# Altium schematic sheet OTP6_PingCircuit.SchDoc(OTP6_PingCircuit)
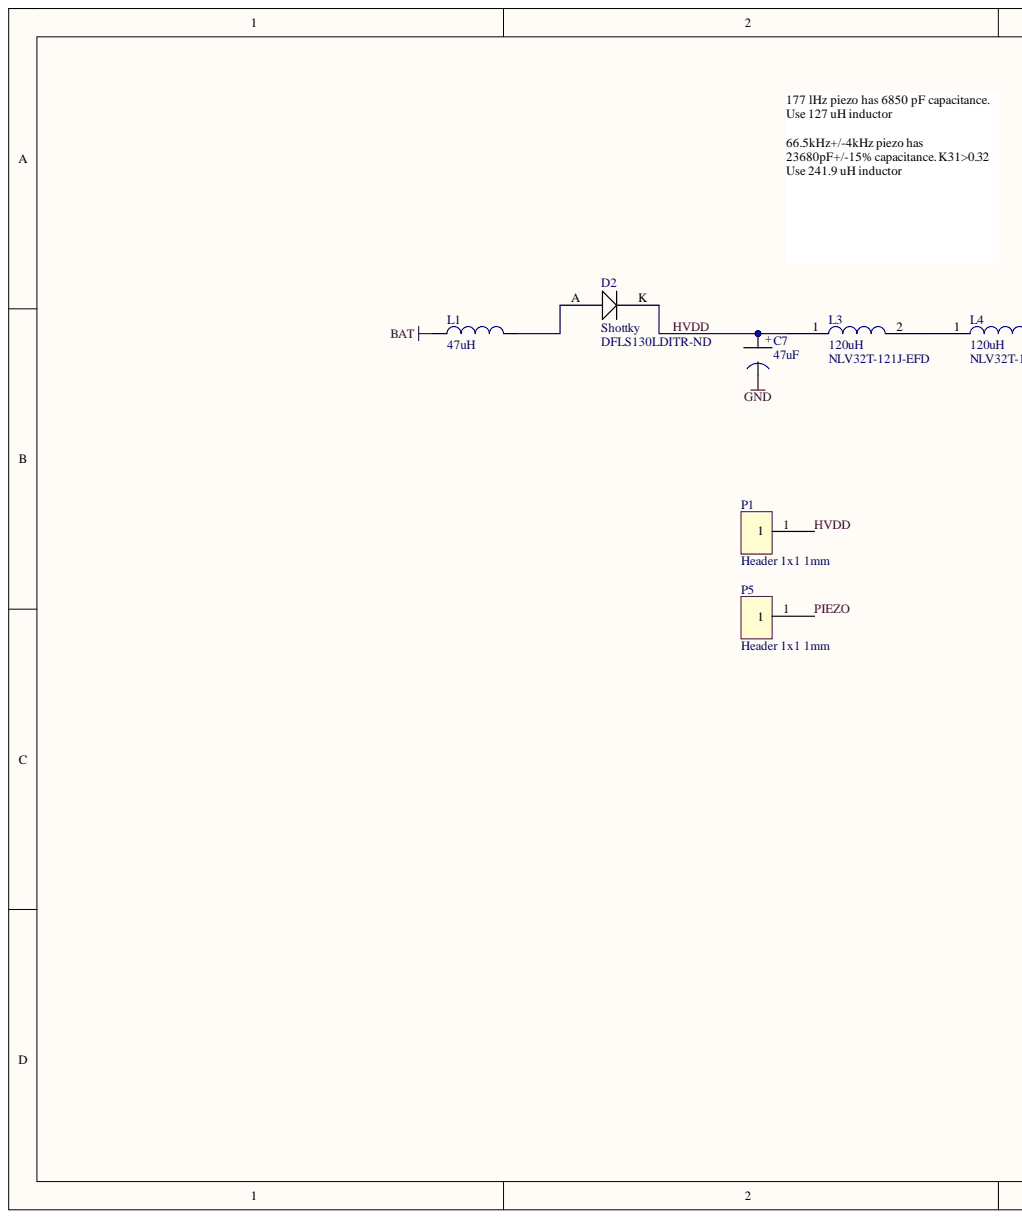
[diagram p0: OTP6_PingCircuit.SchDoc, left]
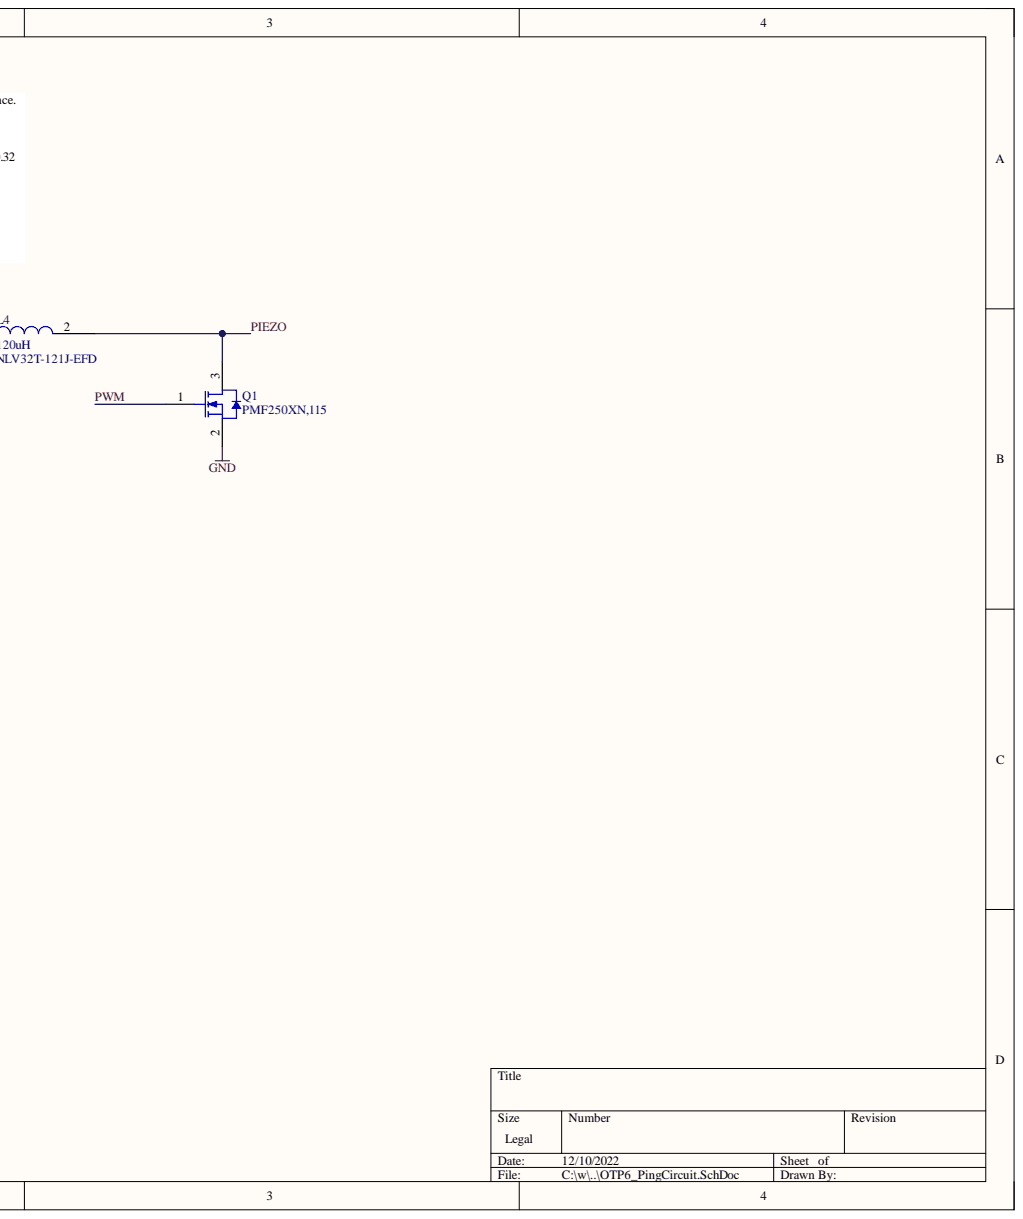
[diagram p1: OTP6_PingCircuit.SchDoc, right]

## Parts
C7  47uF  [Tant0805]
D2  Shottky  Supplier Part Number 1: DFLS130LDITR-ND  [DFLS130]
L1  47uH  [Inductor KLZ1608AHR]
L3  120uH  Supplier Part Number 1: NLV32T-121J-EFD  [Inductor_NLV32T]
L4  120uH  Supplier Part Number 1: NLV32T-121J-EFD  [Inductor_NLV32T]
P1  Header 1x1 1mm  [Header1x1mm]
P5  Header 1x1 1mm  [Header1x1mm]
Q1  PMF250XN,115  [NXP-SOT323_N]

## Nets
BAT: L1.1
GND: C7.1 Q1.2
HVDD: C7.2 D2.K L3.1 P1.1
PIEZO: L4.2 P5.1 Q1.3
PWM: Q1.1
NetD2_A: D2.A L1.2
NetL3_2: L3.2 L4.1

## Library data of the parts
C7 = Tant0805: Value: 10uF; Component Kind: Standard; Library Name: Loggerhead.IntLib
D2 = DFLS130: Component Kind: Standard; Supplier 1: Digi-Key; Library Name: Loggerhead.IntLib
L1 = Inductor KLZ1608: Component Kind: Standard; Supplier 1: Mouser; Supplier Part Number 1: 810-KLZ1608AHR2R2WTD; Library Name: Loggerhead.IntLib
L3 L4 = Inductor_NLV32T: Component Kind: Standard; Supplier 1: Mouser; Library Name: Loggerhead.IntLib
P1 P5 = Header 1x1 1mm: 1mm through hole; Component Kind: Standard; Library Name: Loggerhead.IntLib
Q1 = PMF250XN,115: N-Channel Trench MOSFET, 30 V, 0.9 A, -55 to 150 degC, 3-Pin SOT323, Tape and Reel; Manufacturer: NXP Semiconductors; Channel Type: N; Ciss [typ] (pF): 50; Coss [typ] (pF): 10; ID [max] (A): 0.9; Packing: Tape & Reel; VDS [max] (V): 30; VGSth [typ] (V): 1; Code_JEITA: SC-70; Component Kind: Standard; ComponentLink1Description: Manufacturer URL; ComponentLink1URL: http://www.nxp.com/; ComponentLink2Description: Datasheet; ComponentLink2URL: http://www.nxp.com/documents/data_sheet/PMF250XN.pdf; ComponentLink3Description: Package Specification; ComponentLink3URL: http://www.nxp.com/documents/outline_drawing/sot323_po.pdf; DatasheetVersion: Rev. 1; Library Name: NXP Semiconductors Standard MOSFETs.IntLib; Mounting Technology: Surface Mount; PackageDescription: 3-Pin Small Outline Transistor Package, Body 2 x 1.25 mm, Pitch 0.65 mm; PackageReference: SOT323; PackageVersion: 06-03-16, 03/2006; PartNumber: PMF250XN,115; Supplier 1: Digi-Key; Supplier 2: Digi-Key; Supplier 3: Digi-Key; Supplier 4: Mouser; Supplier Part Number 1: 568-10784-2-ND; Supplier Part Number 2: 568-10784-1-ND; Supplier Part Number 3: 568-10784-6-ND; Supplier Part Number 4: 771-PMF250XN,115
